# Altium schematic sheet Main Schematics.SchDoc("Main Schematics")
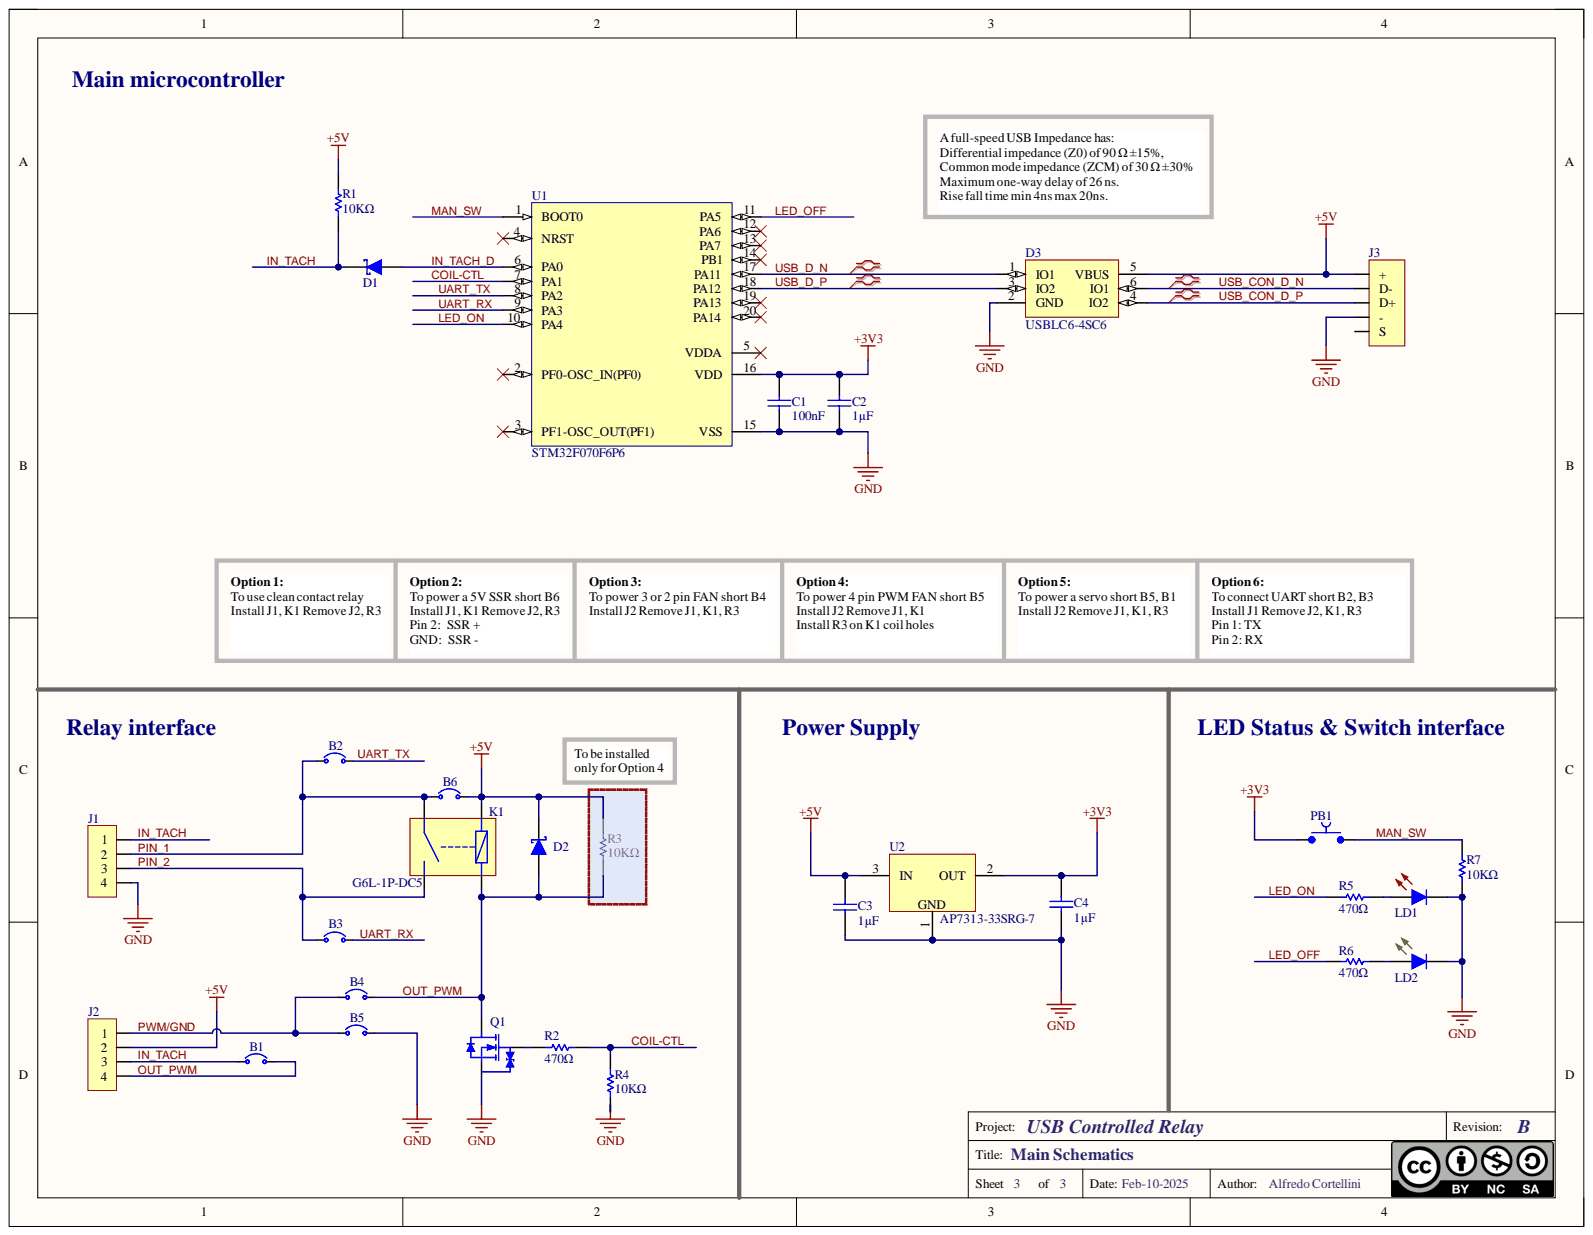
[diagram p0: Main Schematics.SchDoc]

## Parts
B1  _SMD-JUMP-0603  [_SMD-JUMP-0603]  (value not drawn)  (no BOM)
B2  _SMD-JUMP-0603  [_SMD-JUMP-0603]  (value not drawn)  (no BOM)
B3  _SMD-JUMP-0603  [_SMD-JUMP-0603]  (value not drawn)  (no BOM)
B4  _SMD-JUMP-0603  [_SMD-JUMP-0603]  (value not drawn)  (no BOM)
B5  _SMD-JUMP-0603  [_SMD-JUMP-0603]  (value not drawn)  (no BOM)
B6  _SMD-JUMP-0603  [_SMD-JUMP-0603]  (value not drawn)  (no BOM)
C1  100nF  [C0603-H90]
C2  1µF  [C0603-H90]
C3  1µF  [C0603-H90]
C4  1µF  [C0603-H90]
D1  MBR0530T1G  [SOD-323]  (value not drawn)
D2  MBR0530T1G  [SOD-323]  (value not drawn)
D3  USBLC6-4SC6  [SOT-236]
J1  S4B-XH-A(LF)(SN)  [JST S4B-XH-A]  (value not drawn)
J2  CO975  [FAN-HDR-4P-90DEG]  (value not drawn)
J3  48037-0001  [48037-0001]  (value not drawn)
K1  G6L-1P-DC5  [G6L-1P]
LD1  LTST-C191KRKT  [LED-0603-R]  (value not drawn)
LD2  LTST-C191KGKT  [LED-0603-G]  (value not drawn)
PB1  SKQMAQE010  [SKQMA-SERIE]  (value not drawn)
Q1  BSS138K-13  [SOT-23]  (value not drawn)
R1  10KΩ  [R0603-H55]
R2  470Ω  [R0603-H55]
R3  10KΩ  [RAXIAL-1/8W]
R4  10KΩ  [R0603-H55]
R5  470Ω  [R0603-H55]
R6  470Ω  [R0603-H55]
R7  10KΩ  [R0603-H55]
U1  STM32F070F6P6  [TSSOP-20]
U2  AP7313-33SRG-7  [SOT-23]

## Nets
+3V3: C1.2 C2.2 C4.2 PB1.1 U1.16(VDD) U2.2
+5V: B6.1 C3.2 D2.1 D3.5(VBUS) J2.2 J3.1(+) K1.8 R1.2 R3.2 U2.3
GND: B5.1 C1.1 C2.1 C3.1 C4.1 D3.2(GND) J1.4 J3.4(-) LD1.1 LD2.1 Q1.2 R4.1 R7.1 U1.15(VSS) U2.1
COIL-CTL: R2.2 R4.2 U1.7(PA1)
IN_TACH: B1.2 D1.1 J1.1 J2.3 R1.1
IN_TACH_D: D1.2 U1.6(PA0)
LED_OFF: R6.1 U1.11(PA5)
LED_ON: R5.1 U1.10(PA4)
MAN_SW: PB1.2 R7.2 U1.1(BOOT0)
OUT_PWM: B1.1 B4.1 D2.2 J2.4 K1.5 Q1.3 R3.1
PIN_1: B2.2 B6.2 J1.2 K1.2
PIN_2: B3.2 J1.3 K1.4
PWM/GND: B4.2 B5.2 J2.1
UART_RX: B3.1 U1.9(PA3)
UART_TX: B2.1 U1.8(PA2)
USB_CON_D_N: D3.6(IO1) J3.2(D-)
USB_CON_D_P: D3.4(IO2) J3.3(D+)
USB_D_N: D3.1(IO1) U1.17(PA11)
USB_D_P: D3.3(IO2) U1.18(PA12)
NetLD1_2: LD1.2 R5.2
NetLD2_2: LD2.2 R6.2
NetQ1_1: Q1.1 R2.1

Not connected: J3 S; U1 2(PF0-OSC_IN(PF0)) 3(PF1-OSC_OUT(PF1)) 4(NRST) 5(VDDA) 12(PA6) 13(PA7) 14(PB1) 19(PA13) 20(PA14)

## Library data of the parts
B1-B6 = _SMD-JUMP-0603: Option Jumper smd 0603; Case: 0603; Mount: Surface Mount; Library Name: IT Logic Library.IntLib
C1 = CGA3E2X7R1H104K080AA: Cap 0603 Case Ceramic 100nF 50V X7R; Manufacturer: TDK; Value: 100n; Capacitance: 100nF; Case: 0603; Component Kind: Standard; ComponentLink1Description: Data Sheet; ComponentLink1URL: https://product.tdk.com/system/files/dam/doc/product/capacitor/ceramic/mlcc/catalog/mlcc_commercial_general_en.pdf; Dielectric: X7R; Manufacturer Part Number: CGA3E2X7R1H104K080AA; Mount: Surface Mount; Voltage Rating: 50V; Library Name: IT Logic Library.IntLib
C2-C4 = C1608X7R1C105K080AC: Cap 0603 Case Ceramic 1uF 16V X7R; Manufacturer: TDK; Value: 1u; Capacitance: 1uF; Case: 0603; Component Kind: Standard; ComponentLink1Description: Data Sheet; ComponentLink1URL: https://www.murata.com/en-eu/products/productdetail?partno=GRM188Z71C475KE21%23; Dielectric: X7R; Manufacturer Part Number: C1608X7R1C105K080AC; Mount: Surface Mount; Voltage Rating: 16V; Library Name: IT Logic Library.IntLib
D1 D2 = MBR0530T1G: Diode Schottky 30V 0.5A 2-Pin SOD-123; Manufacturer: ON Semiconductor; Case: SOD-123; Component Kind: Standard; ComponentLink1Description: Data Sheet; ComponentLink1URL: https://www.onsemi.com/pdf/datasheet/mbr0530t1-d.pdf; Current Rating: 0.5A; Manufacturer Part Number: MBR0530T1G; Mount: Surface Mount; Library Name: IT Logic Library.IntLib
D3 = USBLC6-4SC6: ESD Protection Diodes / TVS Diodes 2 Line 2.5pF USB 2.0 ESD Protect SOT23-6; Manufacturer: STMicroelectronics; Case: STO23-6; Component Kind: Standard; ComponentLink1Description: Data Sheet; ComponentLink1URL: https://www.mouser.it/datasheet/2/389/usblc6_2-1852789.pdf; Manufacturer Part Number: USBLC6-4SC6; Mount: Surface Mount; Library Name: IT Logic Library.IntLib
J1 = S4B-XH-A(LF)(SN): Conn Shrouded, Series JST XH, 4 Pos Header,(2.50mm) Through Hole, Right Angle Tin; Current Rating: 3A; Voltage Rating: 250V; Component Kind: Standard; ComponentLink1Description: Data Sheet; ComponentLink1URL: https://datasheet.ciiva.com/726/exh-726400.pdf; Manufacturer: JST; Manufacturer Part Number: S4B-XH-A(LF)(SN); Mount: Through Hole; Library Name: IT Logic Library.IntLib
J2 = FC-3+1P-MA: Conn Post Header - PWM Fan - 90 Deg - 4 Pos - Series KK254; Manufacturer: Moddy; Current Rating: 4A; Voltage Rating: 250V; Component Kind: Standard; ComponentLink1Description: Data Sheet; ComponentLink1URL: https://www.moddiy.com/products/4-Pin-3-Pin-2.54mm-Pitch-2510-Fan-Male-Angled-Connector-Black.html?srsltid=AfmBOopaZVadWC9c1TxHb48VLoAs6cq4o3WMRMmuPV4D0msjLx6o7yLs; Manufacturer Part Number: CO975; Mount: Through Hole; Library Name: IT Logic Library.IntLib
J3 = 48037-0001: Conn USB type A plug 4 pin Horizontal TH; Manufacturer: Molex; Current Rating: 1.5A; Voltage Rating: 30V; Component Kind: Standard; ComponentLink1Description: Data Sheet; ComponentLink1URL: https://www.molex.com/en-us/products/part-detail/480370001; Manufacturer Part Number: 48037-0001; Mount: Through Hole; Library Name: IT Logic Library.IntLib
K1 = G6L-1P-DC5: Relay Low Signal Sealed SPST-NO 1A 5VDC Through Hole Tube; Manufacturer: Omron; Component Kind: Standard; ComponentLink1Description: Data Sheet; ComponentLink1URL: http://www.farnell.com/datasheets/1846831.pdf; Manufacturer Part Number: G6L-1P-DC5; Mount: Through Hole; Library Name: IT Logic Library.IntLib
LD1 = LTST-C191KRKT: LED 0603 Top Red 631nm 2-Pin; Manufacturer: Vishay Lite-On; Case: 0603; Component Kind: Standard; ComponentLink1Description: Data Sheet; ComponentLink1URL: https://optoelectronics.liteon.com/upload/download/DS22-2000-223/LTST-C191KRKT.PDF; Library Name: IT Logic Library.IntLib; Manufacturer Part Number: LTST-C191KRKT; Mount: Surface Mount
LD2 = LTST-C191KGKT: LED 0603 Top Green 571nm 2-Pin; Manufacturer: Vishay Lite-On; Case: 0603; Component Kind: Standard; ComponentLink1Description: Data Sheet; ComponentLink1URL: https://optoelectronics.liteon.com/upload/download/DS22-2000-228/LTST-C191KGKT.PDF; Library Name: IT Logic Library.IntLib; Manufacturer Part Number: LTST-C191KGKT; Mount: Surface Mount
PB1 = SKQMAQE010: Tactile Switch Rectangular 6.00x3.55mm. Height 4.3mm. J-Lead 50mA 12VDC 260gf SMD; Manufacturer: Alps Alpine; Voltage Rating: 12V; Component Kind: Standard; ComponentLink1Description: Data Sheet; ComponentLink1URL: https://eu.mouser.com/datasheet/2/15/SKQM-1370716.pdf; Current Rating: 50 mA; Library Name: IT Logic Library.IntLib; Manufacturer Part Number: SKQMAQE010; Mount: Through Hole
Q1 = BSS138K-13: Mosfet N 50V Vds 20V Vgs ESD 1.4R SOT-23; Manufacturer: Diodes; Case: SOT-23; Component Kind: Standard; ComponentLink1Description: Data Sheet; ComponentLink1URL: https://www.diodes.com/assets/Datasheets/BSS138K.pdf; Manufacturer Part Number: BSS138K-13; Mount: Surface Mount; Library Name: IT Logic Library.IntLib
R1 R4 R7 = RC0603FR-0710KL: Resistor 0603 Case 10KR 1% 1/10W; Manufacturer: Yageo; Value: 10K; Voltage Rating: 150V; Case: 0603; Component Kind: Standard; ComponentLink1Description: Data Sheet; ComponentLink1URL: https://datasheet.ciiva.com/2519/pyu-r-int-thick-7-2519712.pdf; Manufacturer Part Number: RC0603FR-0710KL; Mount: Surface Mount; Resistance: 10KR; Library Name: IT Logic Library.IntLib
R2 R5 R6 = RC0603FR-07470RL: Resistor 0603 Case 470R 1% 1/10W; Manufacturer: Yageo; Value: 470; Voltage Rating: 150V; Case: 0603; Component Kind: Standard; ComponentLink1Description: Data Sheet; ComponentLink1URL: https://datasheet.ciiva.com/11808/0900766b8106beab-11808155.pdf; Manufacturer Part Number: RC0603FR-07470RL; Mount: Surface Mount; Resistance: 470R; Library Name: IT Logic Library.IntLib
R3 = RNF18FTD10K0: Resistor Axial 10KR 1% 1/8W 100 ppm; Manufacturer: SEI Stackpole; Value: 10K; Voltage Rating: 200V; Case: Through Hole; Component Kind: Standard; ComponentLink1Description: Data Sheet; ComponentLink1URL: https://www.seielect.com/Catalog/SEI-RNF_RNMF.pdf; Manufacturer Part Number: RNF18FTD10K0; Mount: Through Hole; Resistance: 10KR; Library Name: IT Logic Library.IntLib
U1 = STM32F070F6P6: STM32 Arm Cortex-M0 MCU 32 Kbytes of Flash 48 MHz CPU, USB; Manufacturer: STMicroelectronics; Frequency: 48 MHz; Case: TSSOP-20; Component Kind: Standard; ComponentLink2Description: DataSheet; ComponentLink2URL: http://www.st.com/content/ccc/resource/technical/document/datasheet/31/4f/10/5f/a5/32/46/42/DM00141386.pdf/files/DM00141386.pdf/jcr:content/translations/en.DM00141386.pdf?src-supplier=Digikey; Manufacturer Part Number: STM32F070F6P6; Mount: Surface Mount; Library Name: IT Logic Library.IntLib
U2 = AP7313-33SRG-7: LDO 3.3V 150mA Out  6Vin 130mV Dropout, Quiescent current 65uA Startup 80µs SOT-23; Manufacturer: Diodes; Case: SOT-223; Component Kind: Standard; ComponentLink1Description: Data Sheet; ComponentLink1URL: https://www.diodes.com/assets/Datasheets/AP7313.pdf; Manufacturer Part Number: AP7313-33SRG-7; Mount: Surface Mount; Library Name: IT Logic Library.IntLib
